# Altium schematic sheet ESP32_C3_Calender_Interface_v1_00.SchDoc
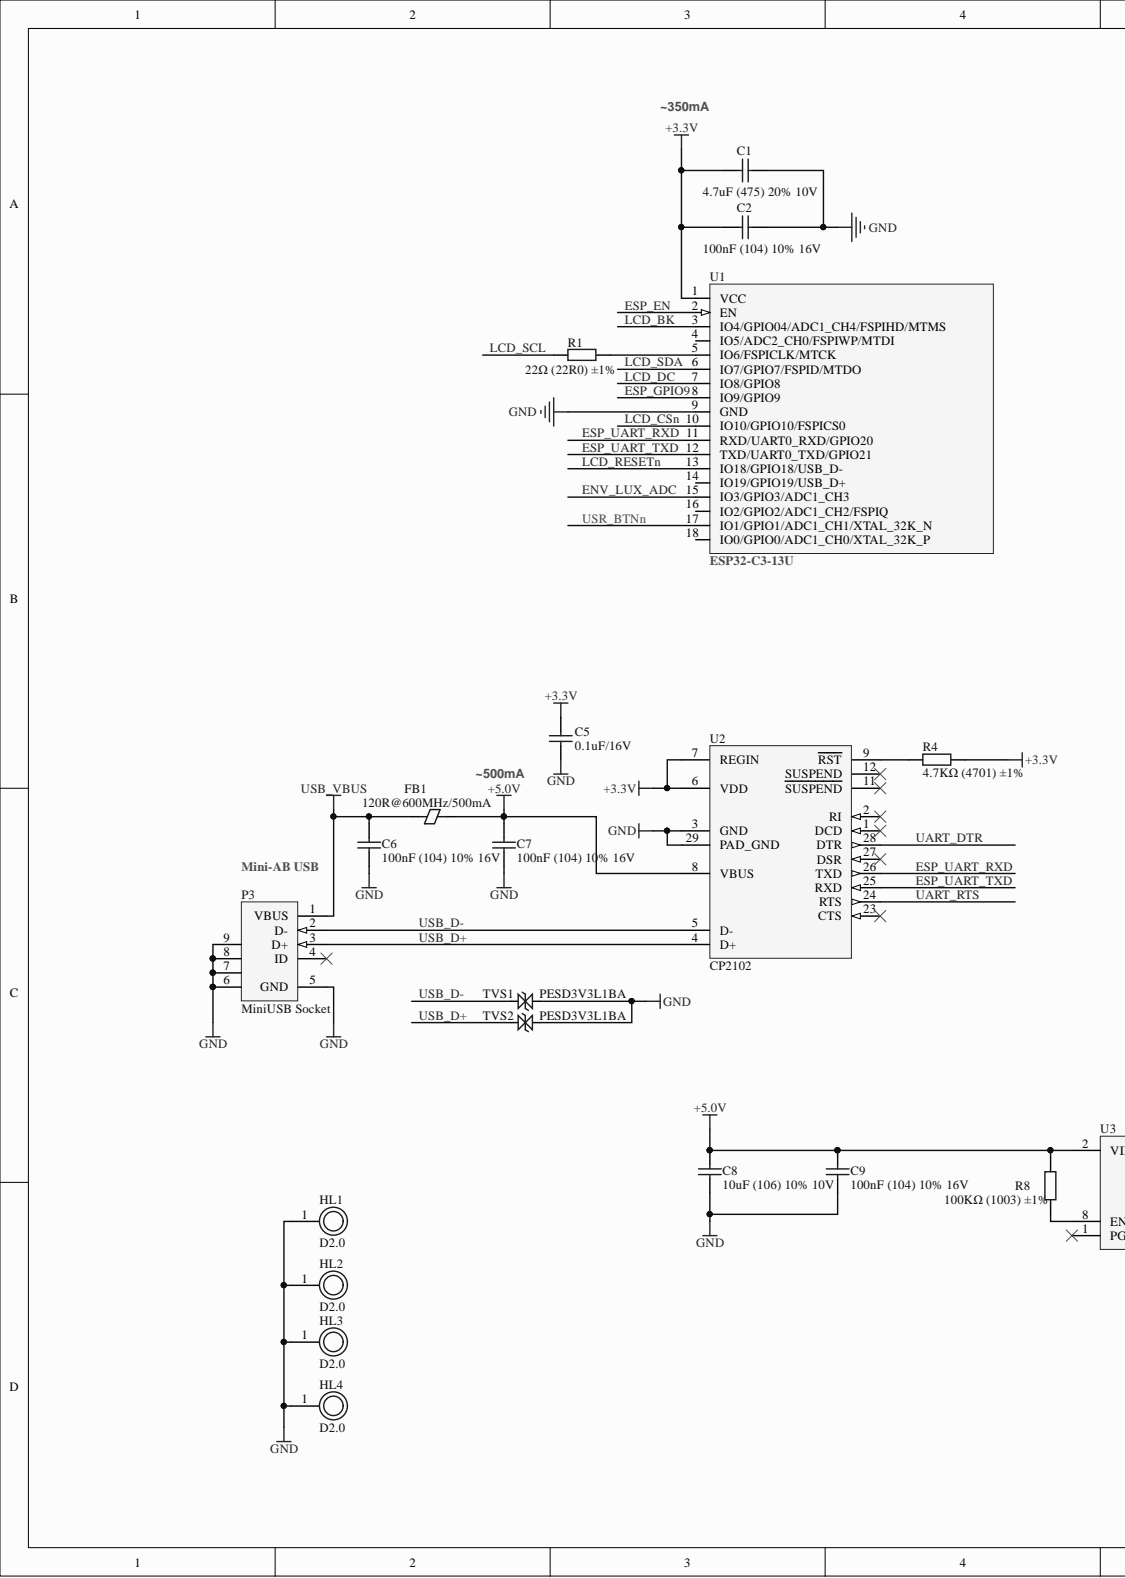
[diagram p0: ESP32_C3_Calender_Interface_v1_00.SchDoc, left]
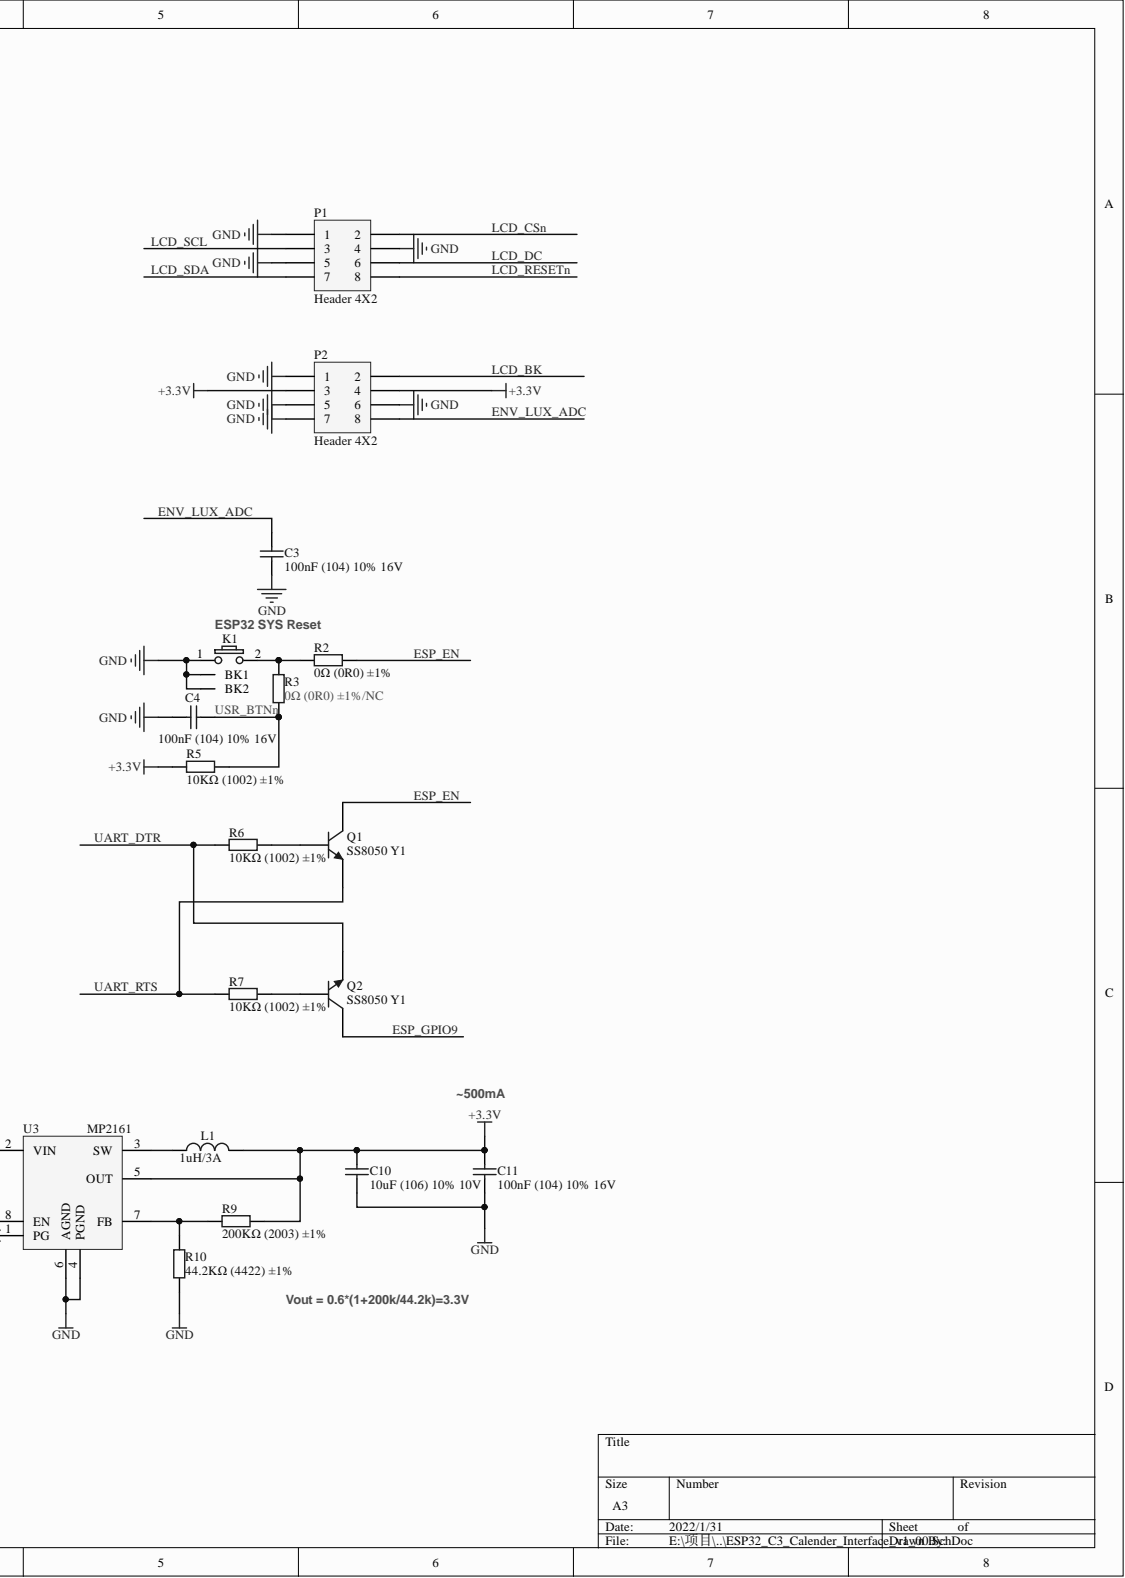
[diagram p1: ESP32_C3_Calender_Interface_v1_00.SchDoc, right]

## Parts
C1  4.7uF (475) 20% 10V  [LC-0402_C]
C2  100nF (104) 10% 16V  [LC-0402_C]
C3  100nF (104) 10% 16V  [LC-0402_C]
C4  100nF (104) 10% 16V  [LC-0402_C]
C5  0.1uF/16V  [LC-0603_C]
C6  100nF (104) 10% 16V  [LC-0402_C]
C7  100nF (104) 10% 16V  [LC-0402_C]
C8  10uF (106) 10% 10V  [LC-0603_C]
C9  100nF (104) 10% 16V  [LC-0402_C]
C10  10uF (106) 10% 10V  [LC-0603_C]
C11  100nF (104) 10% 16V  [LC-0402_C]
FB1  value 120R@600MHz/500mA  [R0603]  (hidden comment: Ferrite bread)
HL1  D2.0  [HOLE_D2.0]
HL2  D2.0  [HOLE_D2.0]
HL3  D2.0  [HOLE_D2.0]
HL4  D2.0  [HOLE_D2.0]
K1  BUTTON  [BUTTON-TH-6X6-R]  (value not drawn)
L1  value 1uH/3A  [INDM2520X10N]  (hidden comment: IND)
P1  Header 4X2  [HDR2X4-P2-SMD-SIDE-CUSTOM]
P2  Header 4X2  [HDR2X4-P2-SMD-SIDE-CUSTOM]
P3  MiniUSB Socket  [USB-F-MINI]
Q1  SS8050 Y1  [LC-SOT-23(SOT-23-3)]
Q2  SS8050 Y1  [LC-SOT-23(SOT-23-3)]
R1  22Ω (22R0) ±1%  [LC-0402_R]
R2  0Ω (0R0) ±1%  [LC-0402_R]
R3  0Ω (0R0) ±1%/NC  [LC-0402_R]
R4  4.7KΩ (4701) ±1%  [LC-0402_R]
R5  10KΩ (1002) ±1%  [LC-0402_R]
R6  10KΩ (1002) ±1%  [LC-0402_R]
R7  10KΩ (1002) ±1%  [LC-0402_R]
R8  100KΩ (1003) ±1%  [LC-0603_R]
R9  200KΩ (2003) ±1%  [LC-0603_R]
R10  44.2KΩ (4422) ±1%  [LC-0603_R]
TVS1  PESD3V3L1BA  [SOD-323B]
TVS2  PESD3V3L1BA  [SOD-323B]
U1  ESP32-C3-13U  [ESP32-C3-13U]
U2  CP2102  [CP2102]
U3  MP2161  [TSOT23-8]

## Nets
+3.3V: C1.1 C2.1 C5.2 C10.2 C11.2 L1.2 P2.3 P2.4 R4.2 R5.1 R9.2 U1.1(VCC) U2.6(VDD) U2.7(REGIN) U3.5(OUT)
+5.0V: C7.2 C8.2 C9.2 FB1.1 R8.2 U2.8(VBUS) U3.2(VIN)
GND: C1.2 C2.2 C3.1 C4.2 C5.1 C6.1 C7.1 C8.1 C9.1 C10.1 C11.1 HL1.1 HL2.1 HL3.1 HL4.1 K1.1 K1.BK1 K1.BK2 P1.1 P1.4 P1.5 P2.1 P2.5 P2.6 P2.7 P3.5(GND) P3.6 P3.7 P3.8 P3.9 R10.1 TVS1.2 TVS2.2 U1.9(GND) U2.3(GND) U2.29(PAD_GND) U3.4(PGND) U3.6(AGND)
USB_VBUS: C6.2 FB1.2 P3.1(VBUS)
ENV_LUX_ADC: C3.2 P2.8 U1.15(IO3/GPIO3/ADC1_CH3)
ESP_EN: Q1.3 R2.2 U1.2(EN)
ESP_GPIO9: Q2.3 U1.8(IO9/GPIO9)
ESP_UART_RXD: U1.11(RXD/UART0_RXD/GPIO20) U2.26(TXD)
ESP_UART_TXD: U1.12(TXD/UART0_TXD/GPIO21) U2.25(RXD)
LCD_BK: P2.2 U1.3(IO4/GPIO04/ADC1_CH4/FSPIHD/MTMS)
LCD_CSn: P1.2 U1.10(IO10/GPIO10/FSPICS0)
LCD_DC: P1.6 U1.7(IO8/GPIO8)
LCD_RESETn: P1.8 U1.13(IO18/GPIO18/USB_D-)
LCD_SCL: P1.3 R1.1
LCD_SDA: P1.7 U1.6(IO7/GPIO7/FSPID/MTDO)
UART_DTR: Q2.2 R6.1 U2.28(DTR)
UART_RTS: Q1.2 R7.1 U2.24(RTS)
USB_D+: P3.3(D+) TVS2.1 U2.4(D+)
USB_D-: P3.2(D-) TVS1.1 U2.5(D-)
USR_BTNn: C4.1 R3.1 R5.2 U1.17(IO1/GPIO1/ADC1_CH1/XTAL_32K_N)
NetK1_2: K1.2 R2.1 R3.2
NetL1_1: L1.1 U3.3(SW)
NetQ1_1: Q1.1 R6.2
NetQ2_1: Q2.1 R7.2
NetR1_2: R1.2 U1.5(IO6/FSPICLK/MTCK)
NetR4_1: R4.1 U2.9(RST)
NetR8_1: R8.1 U3.8(EN)
NetR9_1: R9.1 R10.2 U3.7(FB)

Not connected: P3 4(ID); U1 4(IO5/ADC2_CH0/FSPIWP/MTDI) 14(IO19/GPIO19/USB_D+) 16(IO2/GPIO2/ADC1_CH2/FSPIQ) 18(IO0/GPIO0/ADC1_CH0/XTAL_32K_P); U2 1(DCD) 2(RI) 11(SUSPEND) 12(SUSPEND) 23(CTS) 27(DSR); U3 1(PG)

## Library data of the parts
C1 = 0402 4.7uF (475) 20% 10V: 贴片电容; Component Kind: Standard; ComponentLink1Description: 供应商链接; ComponentLink1URL: http://www.szlcsc.com/product/details_24469.html; Library Name: Miscellaneous Devices LC.IntLib; Package: 0402; Supplier: LC; SuppliersPartNumber: C23733
C2-C4 C6 C7 C9 C11 = 0402 100nF (104) 10% 16V: 贴片电容; Component Kind: Standard; ComponentLink1Description: 供应商链接; ComponentLink1URL: http://www.szlcsc.com/product/details_1877.html; Library Name: Miscellaneous Devices LC.IntLib; Package: 0402; Supplier: LC; SuppliersPartNumber: C1525
C5 = 0603 100nF (104) 10% 50V: 贴片电容; Component Kind: Standard; ComponentLink1Description: 供应商链接; ComponentLink1URL: http://www.szlcsc.com/product/details_15331.html; Library Name: Miscellaneous Devices LC.IntLib; Package: 0603; Supplier: LC; SuppliersPartNumber: C14663
C8 C10 = 0603 10uF (106) 10% 10V: 贴片电容; Component Kind: Standard; ComponentLink1Description: 供应商链接; ComponentLink1URL: http://www.szlcsc.com/product/details_20411.html; Library Name: Miscellaneous Devices LC.IntLib; Package: 0603; Supplier: LC; SuppliersPartNumber: C19702
FB1 = FB: SMD Ferrite bread; Component Kind: Standard; Library Name: SCH-20070319.SCHLIB
HL1-HL4 = HOLE: Mechanical Fix Hole; Component Kind: Standard; Library Name: SCH-20070319.SCHLIB; value: D2.0
K1 = BUTTON2: Key Button; Component Kind: Standard; Library Name: SCH-20070319.SCHLIB
L1 = IND: Inductor; Component Kind: Standard; Library Name: SCH-20070319.SCHLIB
P1 P2 = Header 4X2: Header, 4-Pin, Dual row; Component Kind: Standard; LatestRevisionDate: 17-Jul-2002; LatestRevisionNote: Re-released for DXP Platform.; Library Name: Miscellaneous Connectors.IntLib; Signal Integrity: Connector; Publisher: Altium Limited
P3 = MINIUSB: USB 2.0, Right Angle, SMT, A Type, Receptacle, 5 Position, Black; ContactMaterial: Phosphor Bronze; ContactPlating: Gold (30) over Nickel; DrillSize: Corresponds to nominal value.; PanelGround: Without; PCB_Layout: Complies with manufacturer's recommendation.; value: mini-USB Socket; Component Kind: Standard; ComponentLink1Description: Manufacturer Link; ComponentLink1URL: http://www.tycoelectronics.com/; ComponentLink2Description: Packaging Specification; ComponentLink2URL: http://ecommas.tycoelectronics.com/commerce/DocumentDelivery/DDEController?Action=showdoc&DocId=Customer+Drawing%7F440478%7FB%7Fpdf%7FEnglish%7FC_440478_B.pdf; DatasheetDocument: 15-Nov-2002; HousingMaterial: Thermoplastic; Library Name: SCH-20070319.SCHLIB; PackageDocument: 15-Nov-2002; PackageReference: 440478; Published: 15-Jul-2004; Publisher: Altium Limited
Q1 Q2 = SOT-23 SS8050 Y1: 三极管; Component Kind: Standard; ComponentLink1Description: 供应商链接; ComponentLink1URL: http://www.szlcsc.com/product/details_2507.html; Library Name: Miscellaneous Devices LC.IntLib; Package: SOT-23; Supplier: LC; SuppliersPartNumber: C2150
R1 = 0402 22Ω (22R0) 1%: 贴片电阻; Component Kind: Standard; ComponentLink1Description: 供应商链接; ComponentLink1URL: http://www.szlcsc.com/product/details_25835.html; Library Name: Miscellaneous Devices LC.IntLib; Package: 0402; Supplier: LC; SuppliersPartNumber: C25092
R2 R3 = 0402 0Ω (0R0) 1%: 贴片电阻; Component Kind: Standard; ComponentLink1Description: 供应商链接; ComponentLink1URL: http://www.szlcsc.com/product/details_17853.html; Library Name: Miscellaneous Devices LC.IntLib; Package: 0402; Supplier: LC; SuppliersPartNumber: C17168
R4 = 0402 4.7KΩ (4701) 1%: 贴片电阻; Component Kind: Standard; ComponentLink1Description: 供应商链接; ComponentLink1URL: http://www.szlcsc.com/product/details_26643.html; Library Name: Miscellaneous Devices LC.IntLib; Package: 0402; Supplier: LC; SuppliersPartNumber: C25900
R5-R7 = 0402 10KΩ (1002) 1%: 贴片电阻; Component Kind: Standard; ComponentLink1Description: 供应商链接; ComponentLink1URL: http://www.szlcsc.com/product/details_26487.html; Library Name: Miscellaneous Devices LC.IntLib; Package: 0402; Supplier: LC; SuppliersPartNumber: C25744
R8 = 0603 100KΩ (1003) 1%: 贴片电阻; Component Kind: Standard; ComponentLink1Description: 供应商链接; ComponentLink1URL: http://www.szlcsc.com/product/details_26546.html; Library Name: Miscellaneous Devices LC.IntLib; Package: 0603; Supplier: LC; SuppliersPartNumber: C25803
R9 = 0603 200KΩ (2003) 1%: 贴片电阻; Component Kind: Standard; ComponentLink1Description: 供应商链接; ComponentLink1URL: http://www.szlcsc.com/product/details_26554.html; Library Name: Miscellaneous Devices LC.IntLib; Package: 0603; Supplier: LC; SuppliersPartNumber: C25811
R10 = 0603 43KΩ (4302) 1%: 贴片电阻; Component Kind: Standard; ComponentLink1Description: 供应商链接; ComponentLink1URL: http://www.szlcsc.com/product/details_23899.html; Library Name: Miscellaneous Devices LC.IntLib; Package: 0603; Supplier: LC; SuppliersPartNumber: C23172
TVS1 TVS2 = PESD3V3L1BA: Polymer ESD Devices; Component Kind: Standard; Library Name: SCH-20070319.SCHLIB; Supplier 1: Digi-Key; Supplier Part Number 1: PESD0603-240TR-ND; Value: PESD3V3L1BA
U1 = ESP32-C3-13U: ESP32-C3-13U Module; Component Kind: Standard; Library Name: SCH-20070319.SCHLIB
U2 = CP2102: SINGLE-CHIP USB TO UART BRIDGE; Component Kind: Standard; Library Name: SCH-20070319.SCHLIB; value: CP2102
U3 = MP2161: 2A, 6V, 1.5MHz SYNCHRONOUS STEP-DOWN SWITCHER; Component Kind: Standard; Library Name: SCH-20070319.SCHLIB
